# Altium schematic sheet LIDO-HW.200.MCU_Peripherals_1.SchDoc(LIDO-HW.200.MCU_Peripherals_1)
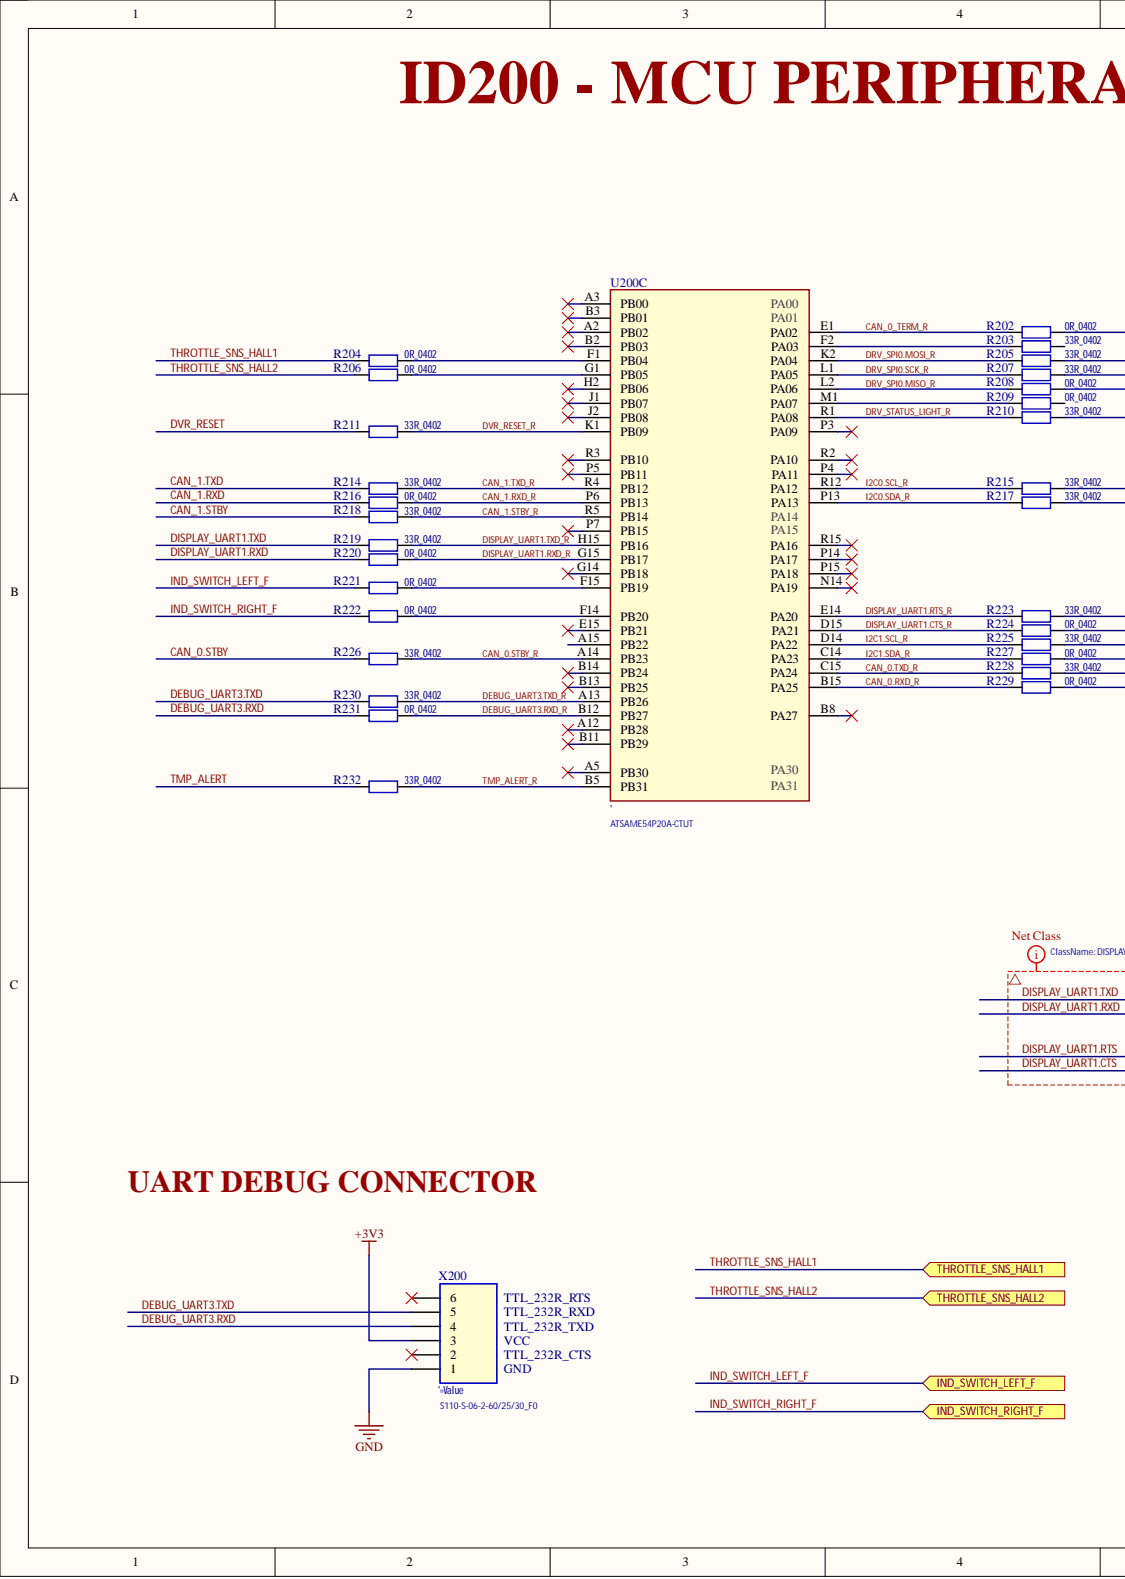
[diagram p0: LIDO-HW.200.MCU_Peripherals_1.SchDoc, left]
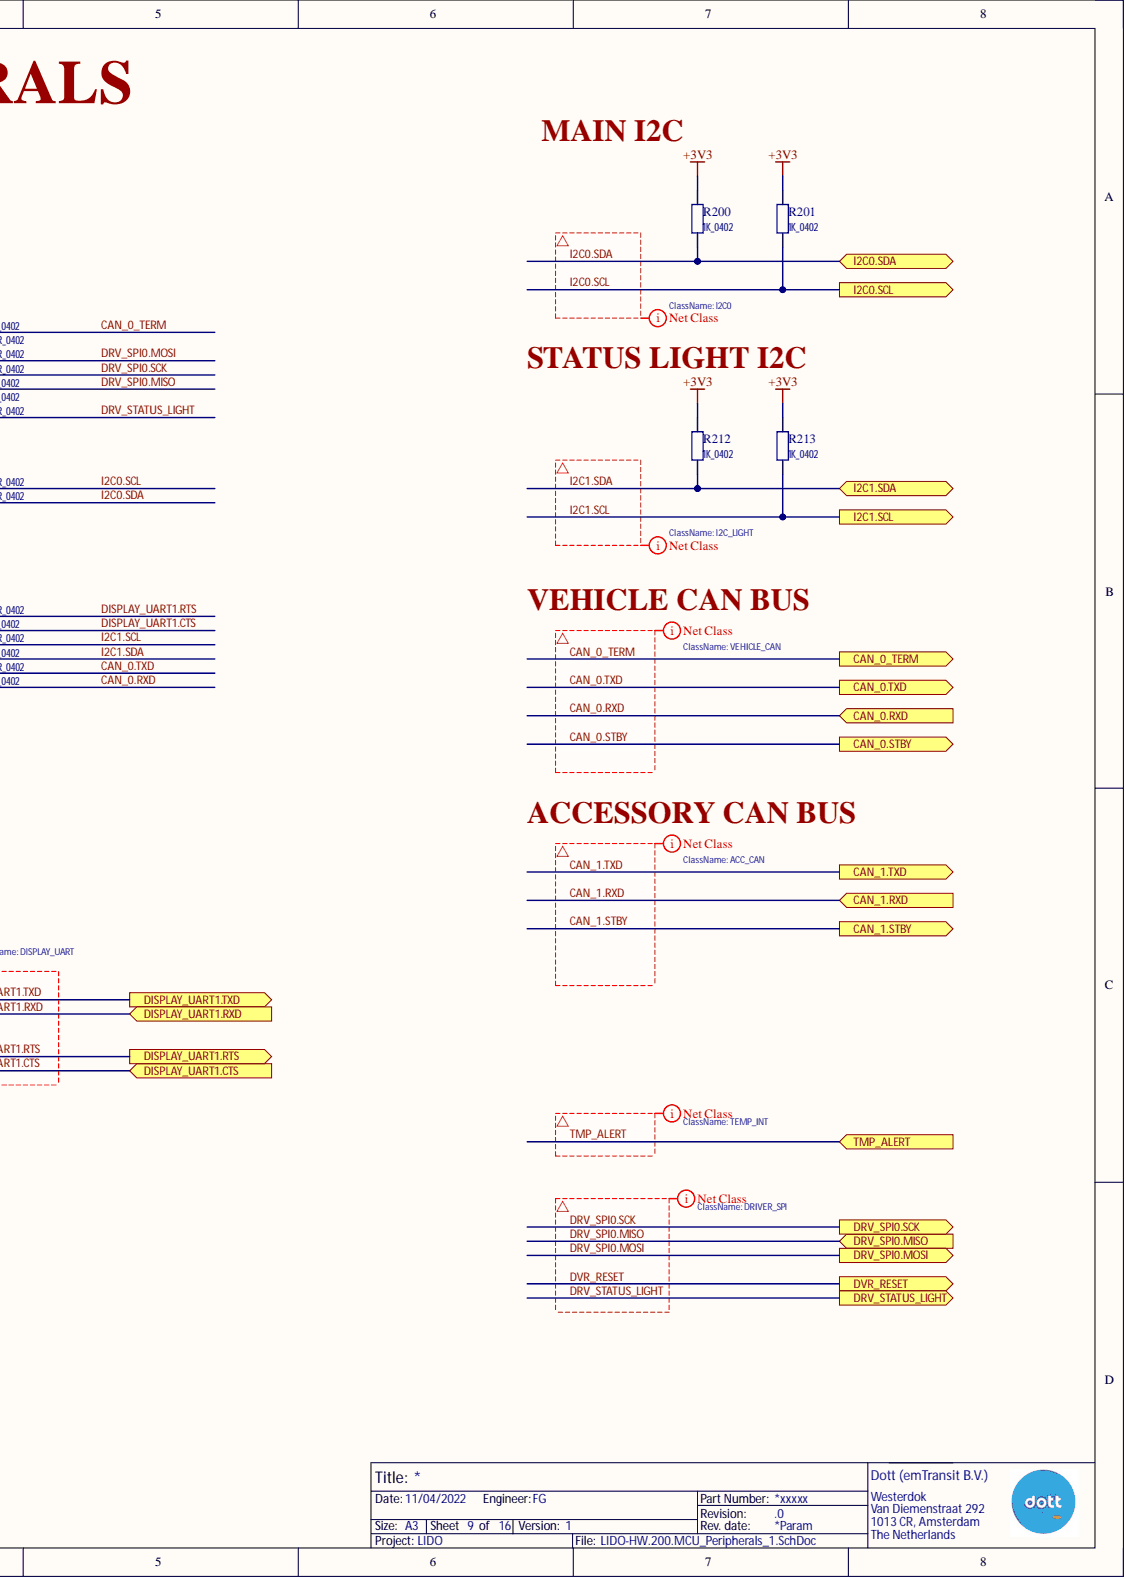
[diagram p1: LIDO-HW.200.MCU_Peripherals_1.SchDoc, right]

## Parts
R200  1K_0402
R201  1K_0402
R202  0R_0402
R203  33R_0402
R204  0R_0402
R205  33R_0402
R206  0R_0402
R207  33R_0402
R208  0R_0402
R209  0R_0402
R210  33R_0402
R211  33R_0402
R212  1K_0402
R213  1K_0402
R214  33R_0402
R215  33R_0402
R216  0R_0402
R217  33R_0402
R218  33R_0402
R219  33R_0402
R220  0R_0402
R221  0R_0402
R222  0R_0402
R223  33R_0402
R224  0R_0402
R225  33R_0402
R226  33R_0402
R227  0R_0402
R228  33R_0402
R229  0R_0402
R230  33R_0402
R231  0R_0402
R232  33R_0402
U200  '  (part C)
X200  '=Value

## Ports
CAN_0.RXD, CAN_0.STBY, CAN_0.TXD, CAN_0_TERM, CAN_1.RXD, CAN_1.STBY, CAN_1.TXD, DISPLAY_UART1.CTS, DISPLAY_UART1.RTS, DISPLAY_UART1.RXD, DISPLAY_UART1.TXD, DRV_SPI0.MISO, DRV_SPI0.MOSI, DRV_SPI0.SCK, DRV_STATUS_LIGHT, DVR_RESET, I2C0.SCL, I2C0.SDA, I2C1.SCL, I2C1.SDA, IND_SWITCH_LEFT_F, IND_SWITCH_RIGHT_F, THROTTLE_SNS_HALL1, THROTTLE_SNS_HALL2, TMP_ALERT

## Nets
+3V3: R200.1 R201.1 R212.1 R213.1 X200.3
GND: X200.1
CAN_0.RXD: R202.1 R202.2 R229.1 U200.E1(PA02) ; port CAN_0.RXD, port CAN_0_TERM  [also labelled CAN_0_TERM, CAN_0_TERM_R]
CAN_0.RXD_R: R229.2 U200.B15(PA25)
CAN_0.STBY: R226.2 ; port CAN_0.STBY
CAN_0.STBY_R: R226.1 U200.A14(PB23)
CAN_0.TXD: R228.1 ; port CAN_0.TXD
CAN_0.TXD_R: R228.2 U200.C15(PA24)
CAN_1.RXD: R216.2 ; port CAN_1.RXD
CAN_1.RXD_R: R216.1 U200.P6(PB13)
CAN_1.STBY: R218.2 ; port CAN_1.STBY
CAN_1.STBY_R: R218.1 U200.R5(PB14)
CAN_1.TXD: R214.2 ; port CAN_1.TXD
CAN_1.TXD_R: R214.1 U200.R4(PB12)
DEBUG_UART3.RXD: R231.2 X200.4
DEBUG_UART3.RXD_R: R231.1 U200.B12(PB27)
DEBUG_UART3.TXD: R230.2 X200.5
DEBUG_UART3.TXD_R: R230.1 U200.A13(PB26)
DISPLAY_UART1.CTS: R224.1 ; port DISPLAY_UART1.CTS
DISPLAY_UART1.CTS_R: R224.2 U200.D15(PA21)
DISPLAY_UART1.RTS: R223.1 ; port DISPLAY_UART1.RTS
DISPLAY_UART1.RTS_R: R223.2 U200.E14(PA20)
DISPLAY_UART1.RXD: R220.2 ; port DISPLAY_UART1.RXD
DISPLAY_UART1.RXD_R: R220.1 U200.G15(PB17)
DISPLAY_UART1.TXD: R219.2 ; port DISPLAY_UART1.TXD
DISPLAY_UART1.TXD_R: R219.1 U200.H15(PB16)
DRV_SPI0.MISO: R208.1 ; port DRV_SPI0.MISO
DRV_SPI0.MISO_R: R208.2 U200.L2(PA06)
DRV_SPI0.MOSI: R205.1 ; port DRV_SPI0.MOSI
DRV_SPI0.MOSI_R: R205.2 U200.K2(PA04)
DRV_SPI0.SCK: R207.1 ; port DRV_SPI0.SCK
DRV_SPI0.SCK_R: R207.2 U200.L1(PA05)
DRV_STATUS_LIGHT: R210.1 ; port DRV_STATUS_LIGHT
DRV_STATUS_LIGHT_R: R210.2 U200.R1(PA08)
DVR_RESET: R211.2 ; port DVR_RESET
DVR_RESET_R: R211.1 U200.K1(PB09)
I2C0.SCL: R201.2 R215.1 ; port I2C0.SCL
I2C0.SCL_R: R215.2 U200.R12(PA12)
I2C0.SDA: R200.2 R217.1 ; port I2C0.SDA
I2C0.SDA_R: R217.2 U200.P13(PA13)
I2C1.SCL: R213.2 R225.1 ; port I2C1.SCL
I2C1.SCL_R: R225.2 U200.D14(PA22)
I2C1.SDA: R212.2 R227.1 ; port I2C1.SDA
I2C1.SDA_R: R227.2 U200.C14(PA23)
IND_SWITCH_LEFT_F: R221.2 ; port IND_SWITCH_LEFT_F
IND_SWITCH_RIGHT_F: R222.2 ; port IND_SWITCH_RIGHT_F
THROTTLE_SNS_HALL1: R204.2 ; port THROTTLE_SNS_HALL1
THROTTLE_SNS_HALL2: R206.2 ; port THROTTLE_SNS_HALL2
TMP_ALERT: R232.2 ; port TMP_ALERT
TMP_ALERT_R: R232.1 U200.B5(PB31)
NetR203_2: R203.2 U200.F2(PA03)
NetR204_1: R204.1 U200.F1(PB04)
NetR206_1: R206.1 U200.G1(PB05)
NetR209_2: R209.2 U200.M1(PA07)
NetR221_1: R221.1 U200.F15(PB19)
NetR222_1: R222.1 U200.F14(PB20)

Not connected: R203 1; R209 1; U200 A2(PB02) A3(PB00) A5(PB30) A12(PB28) A15(PB22) B2(PB03) B3(PB01) B8(PA27) B11(PB29) B13(PB25) B14(PB24) E15(PB21) G14(PB18) H2(PB06) J1(PB07) J2(PB08) N14(PA19) P3(PA09) P4(PA11) P5(PB11) P7(PB15) P14(PA17) P15(PA18) R2(PA10) R3(PB10) R15(PA16); X200 2 6

## Library data of the parts
R200-R232 = Resistor: Resistor Symbol; Component Kind: Standard; Library Name: 02_Resistors.SchLib
U200 = ATMEL_ATSAME54P20A-CT_A: 32-bit ARM® Cortex®-M4F MCUs with 1 Msps 12-bit ADC,  QSPI, USB, Ethernet, and PTC; Component Kind: Standard; Library Name: LIDO_Lib.SchLib
X200 = RECT_6pin_A: Rectengular connector, 6 pins, Version A; Component Kind: Standard; Library Name: 40_Connectors_Rectangular.SchLib
